# Altium schematic sheet ZYNQ_Core.SchDoc(ZYNQ_Core)
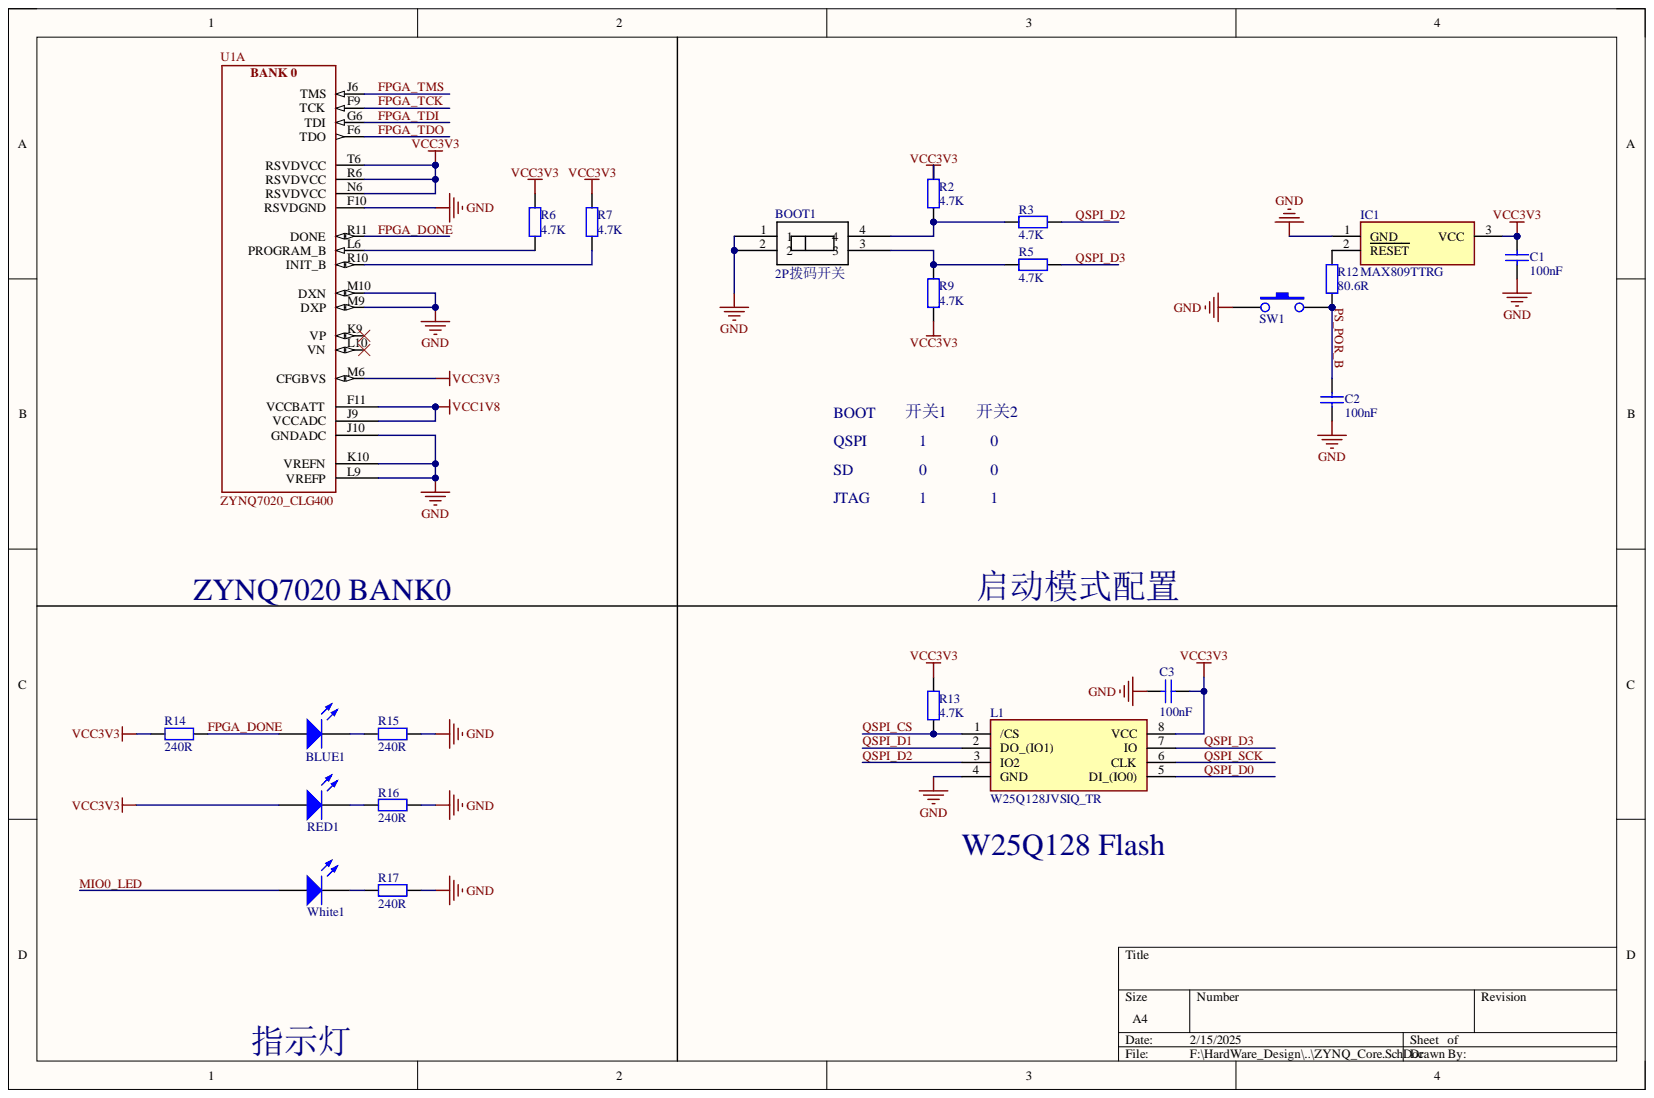
[diagram p0: ZYNQ_Core.SchDoc]

## Parts
BLUE1  LED  [LED 0402 B]  (value not drawn)
BOOT1  2P拨码开关  [CSW1.27 SMD-2P]
C1  100nF  [C 0402_L]
C2  100nF  [C 0402_L]
C3  100nF  [C 0402_L]
IC1  MAX809TTRG  [SOT96P237X111-3N]
L1  W25Q128JVSIQ_TR  [SOIC127P780X195-8N]
R2  4.7K  [R 0402_L]
R3  4.7K  [R 0402_L]
R5  4.7K  [R 0402_L]
R6  4.7K  [R 0402_L]
R7  4.7K  [R 0402_L]
R9  4.7K  [R 0402_L]
R12  80.6R  [R 0402_L]
R13  4.7K  [R 0402_L]
R14  240R  [R 0402_L]
R15  240R  [R 0402_L]
R16  240R  [R 0402_L]
R17  240R  [R 0402_L]
RED1  LED  [LED 0402 R]  (value not drawn)
SW1  按键  [SWT3*4]  (value not drawn)
U1  ZYNQ7020_CLG400  [BGA400C80P20X20_1700X1700X160]  (part A)
White1  LED  [LED 0402 G]  (value not drawn)

## Nets
GND: BOOT1.1 BOOT1.2 C1.1 C2.1 C3.2 IC1.1 L1.4(GND) R15.1 R16.1 R17.1 SW1.1 U1.F10(RSVDGND) U1.J10(GNDADC) U1.K10(VREFN) U1.L9(VREFP) U1.M9(DXP) U1.M10(DXN)
VCC1V8: U1.F11(VCCBATT) U1.J9(VCCADC)
VCC3V3: C1.2 C3.1 IC1.3 L1.8(VCC) R2.2 R6.2 R7.2 R9.1 R13.1 R14.1 RED1.1 U1.M6(CFGBVS) U1.N6(RSVDVCC) U1.R6(RSVDVCC) U1.T6(RSVDVCC)
FPGA_DONE: BLUE1.1 R14.2 U1.R11(DONE)
FPGA_TCK: U1.F9(TCK)
FPGA_TDI: U1.G6(TDI)
FPGA_TDO: U1.F6(TDO)
FPGA_TMS: U1.J6(TMS)
MIO0_LED: White1.1
PS_POR_B: C2.2 R12.2 SW1.2
QSPI_CS: L1.1(/CS) R13.2
QSPI_D0: L1.5(DI_(IO0))
QSPI_D1: L1.2(DO_(IO1))
QSPI_D2: L1.3(IO2) R3.1
QSPI_D3: L1.7(IO) R5.1
QSPI_SCK: L1.6(CLK)
NetBLUE1_2: BLUE1.2 R15.2
NetBOOT1_3: BOOT1.3 R5.2 R9.2
NetBOOT1_4: BOOT1.4 R2.1 R3.2
NetIC1_2: IC1.2 R12.1
NetR6_1: R6.1 U1.L6(PROGRAM_B)
NetR7_1: R7.1 U1.R10(INIT_B)
NetR16_2: R16.2 RED1.2
NetR17_2: R17.2 White1.2

Not connected: U1 K9(VP) L10(VN)

## Library data of the parts
C1-C3 = Cap1: Component Kind: Standard; value: 100nF; Library Name: 零散元件库2.SCHLIB
IC1 = MAX809TTRG: Integrated Circuit; Manufacturer_Name: onsemi; Arrow Part Number: MAX809TTRG; Arrow Price/Stock: https://www.arrow.com/en/products/max809ttrg/on-semiconductor; Component Kind: Standard; Datasheet Link: http://www.onsemi.com/pub/Collateral/MAX809S-D.PDF; Height: 1.11mm; Library Name: SamacSys.SchLib; Manufacturer_Part_Number: MAX809TTRG; Mouser Part Number: 863-MAX809TTRG; Mouser Price/Stock: https://www.mouser.co.uk/ProductDetail/onsemi/MAX809TTRG?qs=3JMERSakebpLWYPNGFPMSQ%3D%3D
L1 = W25Q128JVSIQ_TR: Inductor; Manufacturer_Name: Winbond; Manufacturer_Part_Number: W25Q128JVSIQ TR; Arrow Part Number: W25Q128JVSIQ TR; Arrow Price/Stock: https://www.arrow.com/en/products/w25q128jvsiqtr/winbond-electronics?utm_currency=USD&region=nac; Component Kind: Standard; Datasheet Link: http://www.winbond.com/resource-files/w25q128jv%20spi%20revc%2011162016.pdf; Height: 1.95mm; Library Name: SamacSys.SchLib; Mouser Part Number: 454-W25Q128JVSIQTR; Mouser Price/Stock: https://www.mouser.co.uk/ProductDetail/Winbond/W25Q128JVSIQ-TR?qs=qSfuJ%252Bfl%2Fd7vTyLA9kNdGw%3D%3D
R2 R3 R5-R7 R9 R13-R17 = Res: Component Kind: Standard
  R2 R3 R5-R7 R9 R13: value: 4.7K
  R14-R17: value: 240R
  R2 R3 R5-R7 R9 R14-R17: Library Name: 零散元件-V1.1.SCHLIB
  R13: Library Name: 零散元件库2.SCHLIB
R12 = Res_1: Component Kind: Standard; value: 80.6R; Library Name: 零散元件库2.SCHLIB
U1 = XC7Z020: Zynq 7000 FPGA 20 Die, in a CSG 484 Package; Manufacturer: Xilinx; Component Kind: Standard; Library Name: Miscellaneous Devices.IntLib
BLUE1 RED1 White1 = LED: Component Kind: Standard; Library Name: 零散元件-V1.1.SCHLIB
BOOT1 = 2P拨码开关: Component Kind: Standard; Library Name: ZYNQ_开发板.IntLib
SW1 = 按键: Component Kind: Standard; Library Name: 零散元件-V1.1.SCHLIB
